# Altium schematic sheet IRSensor.SchDoc
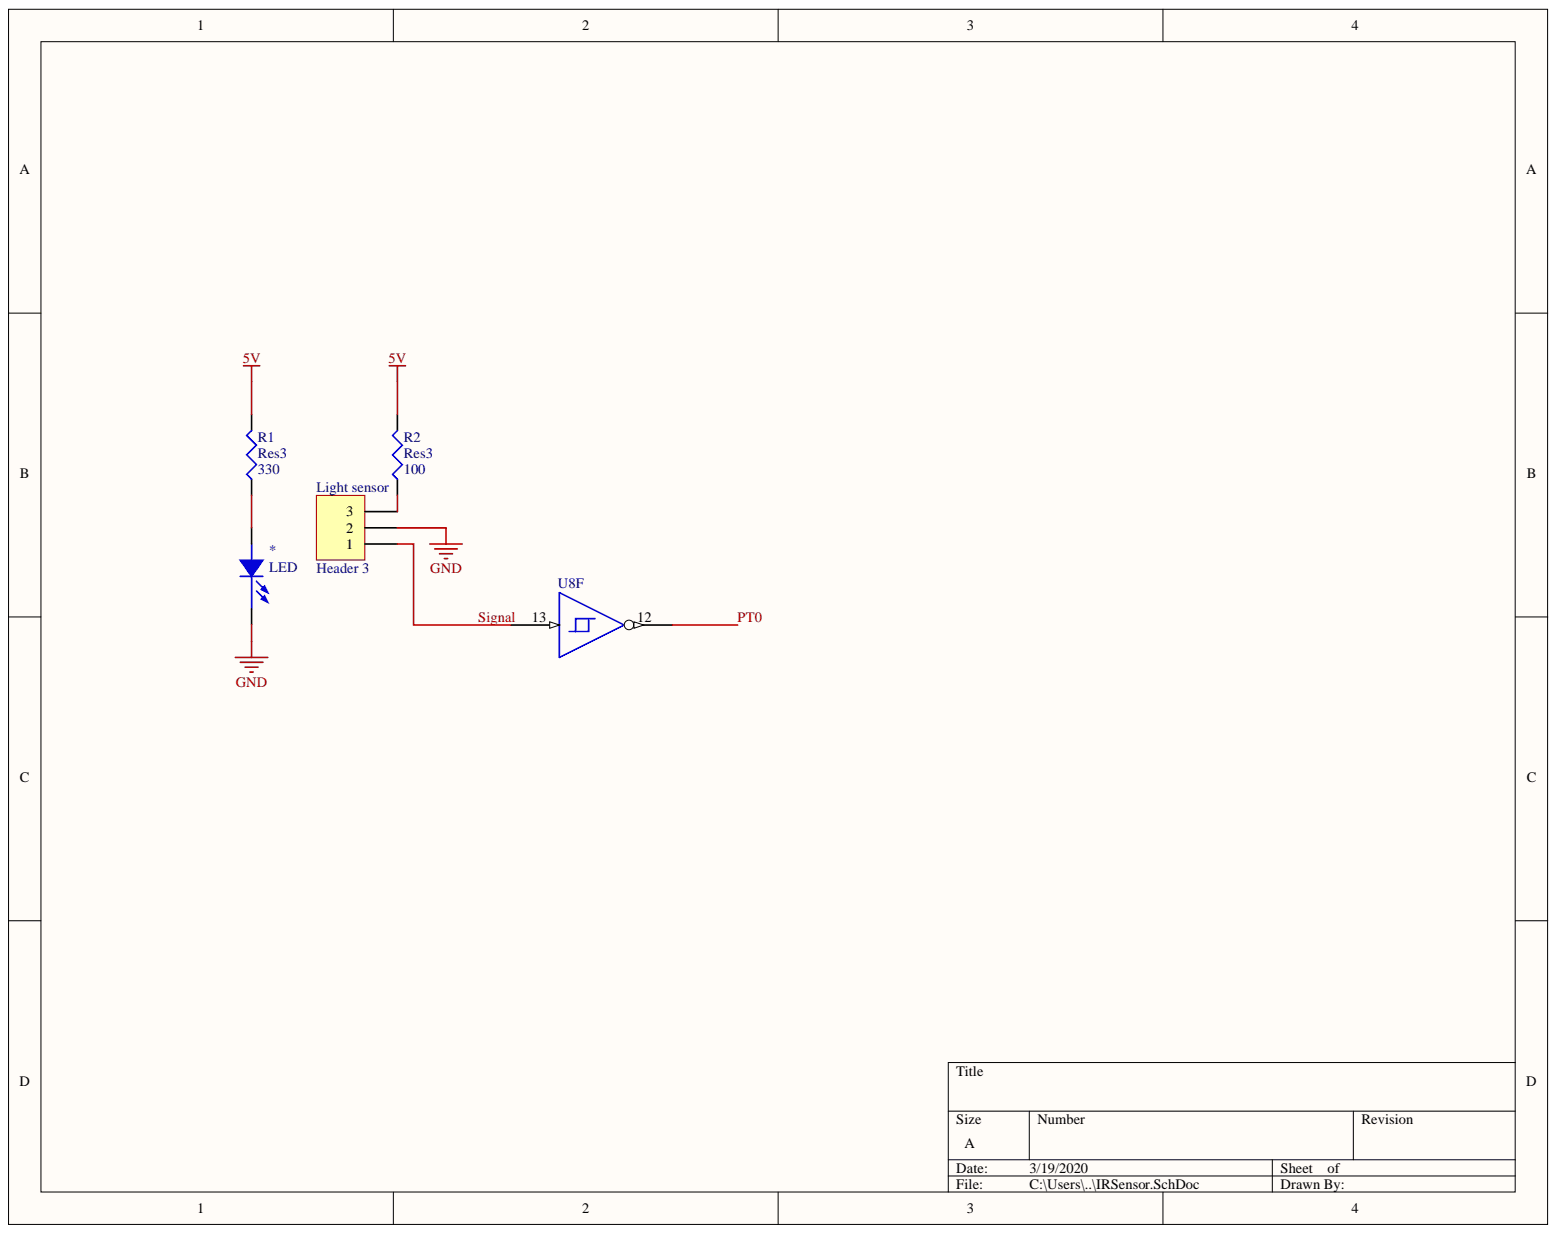
[diagram p0: IRSensor.SchDoc]

## Parts
*  LED  [LED]
Light_sensor  Header 3  [HDR1X3]
R1  Res3  value 330  [RESC2112X05M]
R2  Res3  value 100  [RESC2112X05M]
U8  (no value)  [SOIC127P600X175-14M]  (part F)

## Nets
5V: R1.2 R2.2
GND: *.2 Light_sensor.2
PT0: U8.12
Signal: Light_sensor.1 U8.13
Net*_1: *.1 R1.1
NetLight sensor_3: Light_sensor.3 R2.1

## Library data of the parts
Light_sensor = Header 3: Header, 3-Pin Male; MPN: PEC03SFAN; PN: S1212E-03-ND; Component Kind: Standard; URL: http://www.digikey.ca/product-detail/en/PEC03SFAN/S1212E-03-ND; Library Name: esd_libraryv2.IntLib
R1 R2 = Resistor_976K: RES SMD 976K OHM 1% 1/8W 0805; MPN: CRCW0805976KFKEA; PN: 541-976KCCT-ND; Component Kind: Standard; Name: Resistor_976K; URL: http://www.digikey.ca/product-detail/en/CRCW0805976KFKEA/541-976KCCT-ND; Library Name: esd_libraryv2.IntLib
U8 = 74ACT14_3: IC INVERTER HEX SCHMITT 14SOIC; MPN: 74ACT14SCX; PN: 74ACT14SCXCT-ND; Component Kind: Standard; Library Name: esd_library.IntLib; URL: http://www.digikey.ca/product-detail/en/74ACT14SCX/74ACT14SCXCT-ND
* = LED: Component Kind: Standard; Library Name: CustomComponants.SchLib
